# Altium schematic sheet eACU_mcux.SchDoc
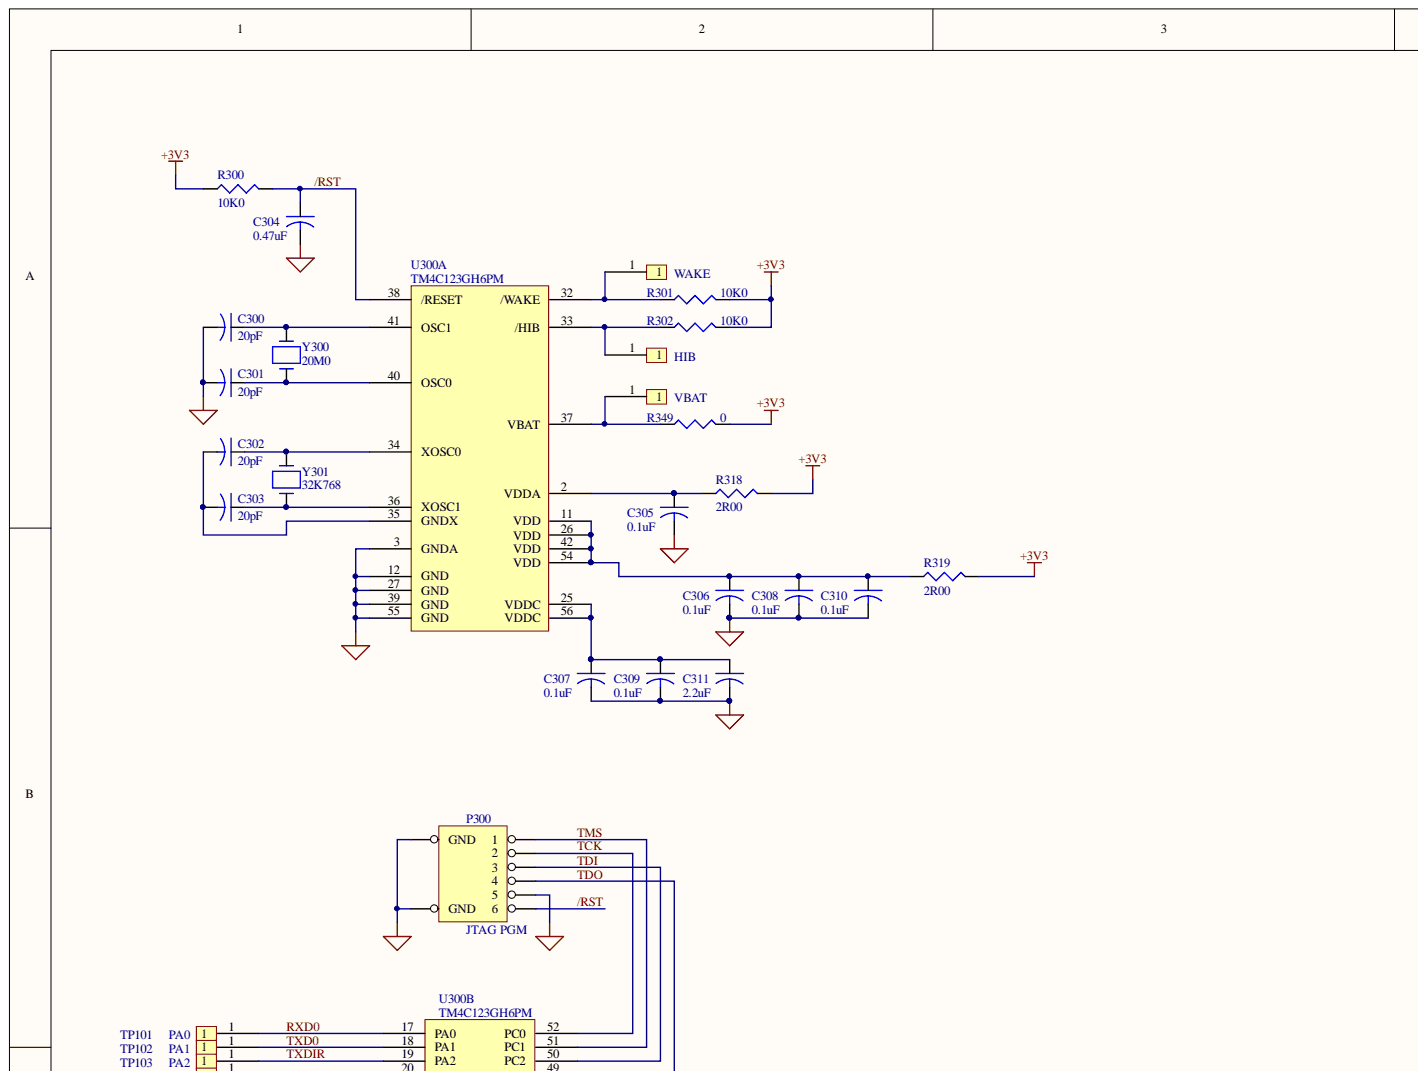
[diagram p0: eACU_mcux.SchDoc, top left]
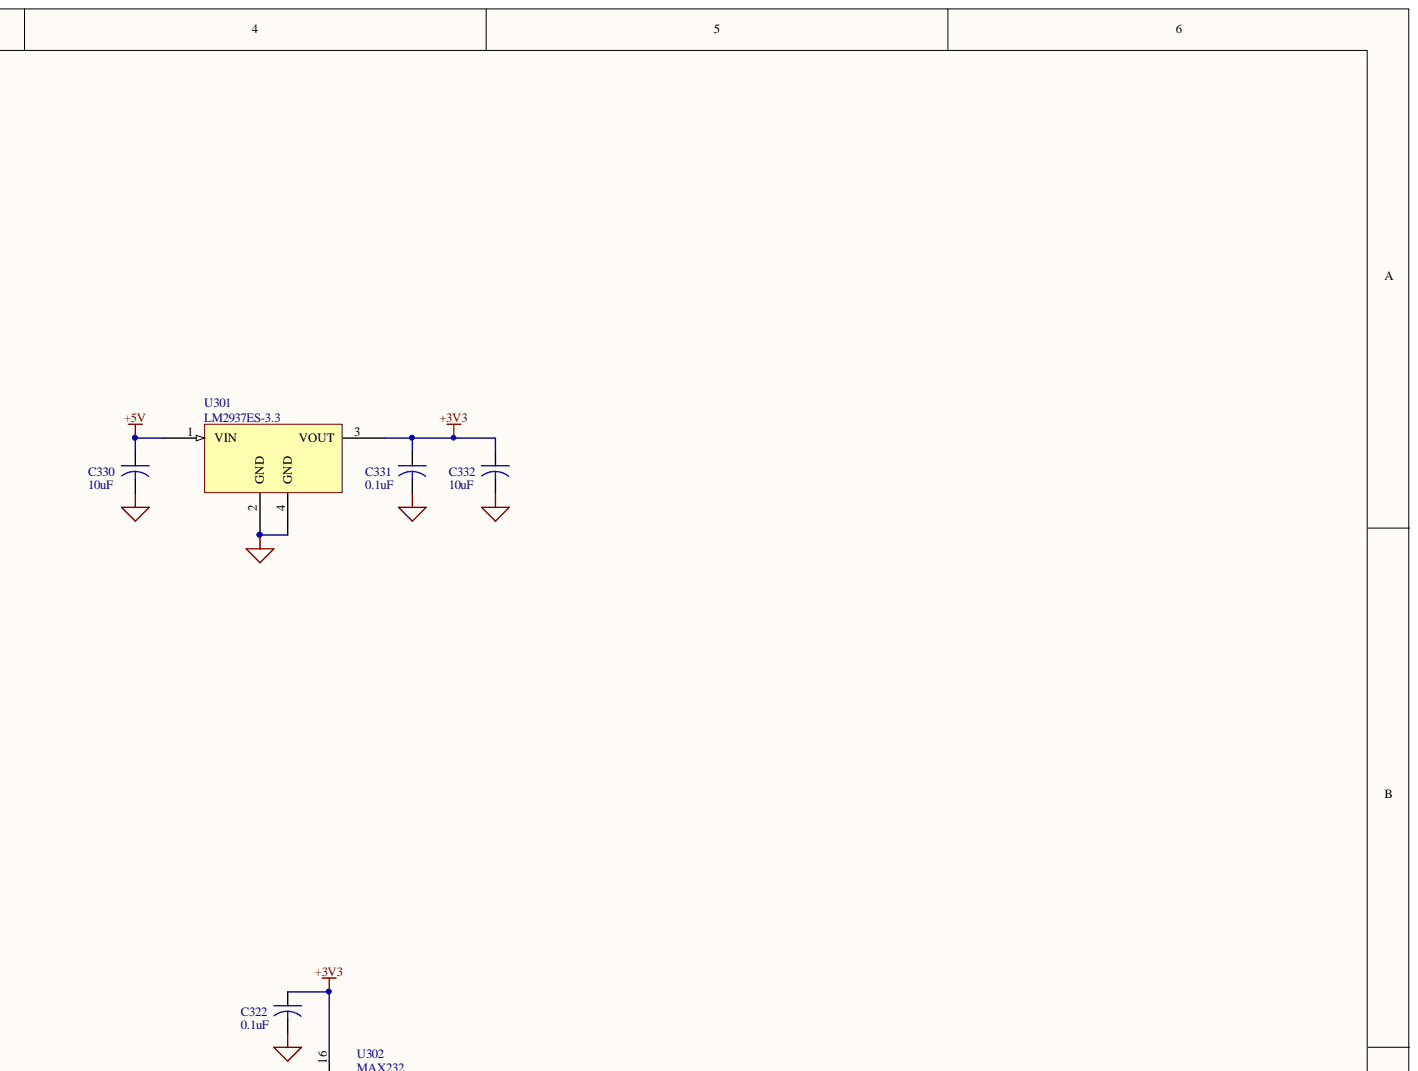
[diagram p1: eACU_mcux.SchDoc, top right]
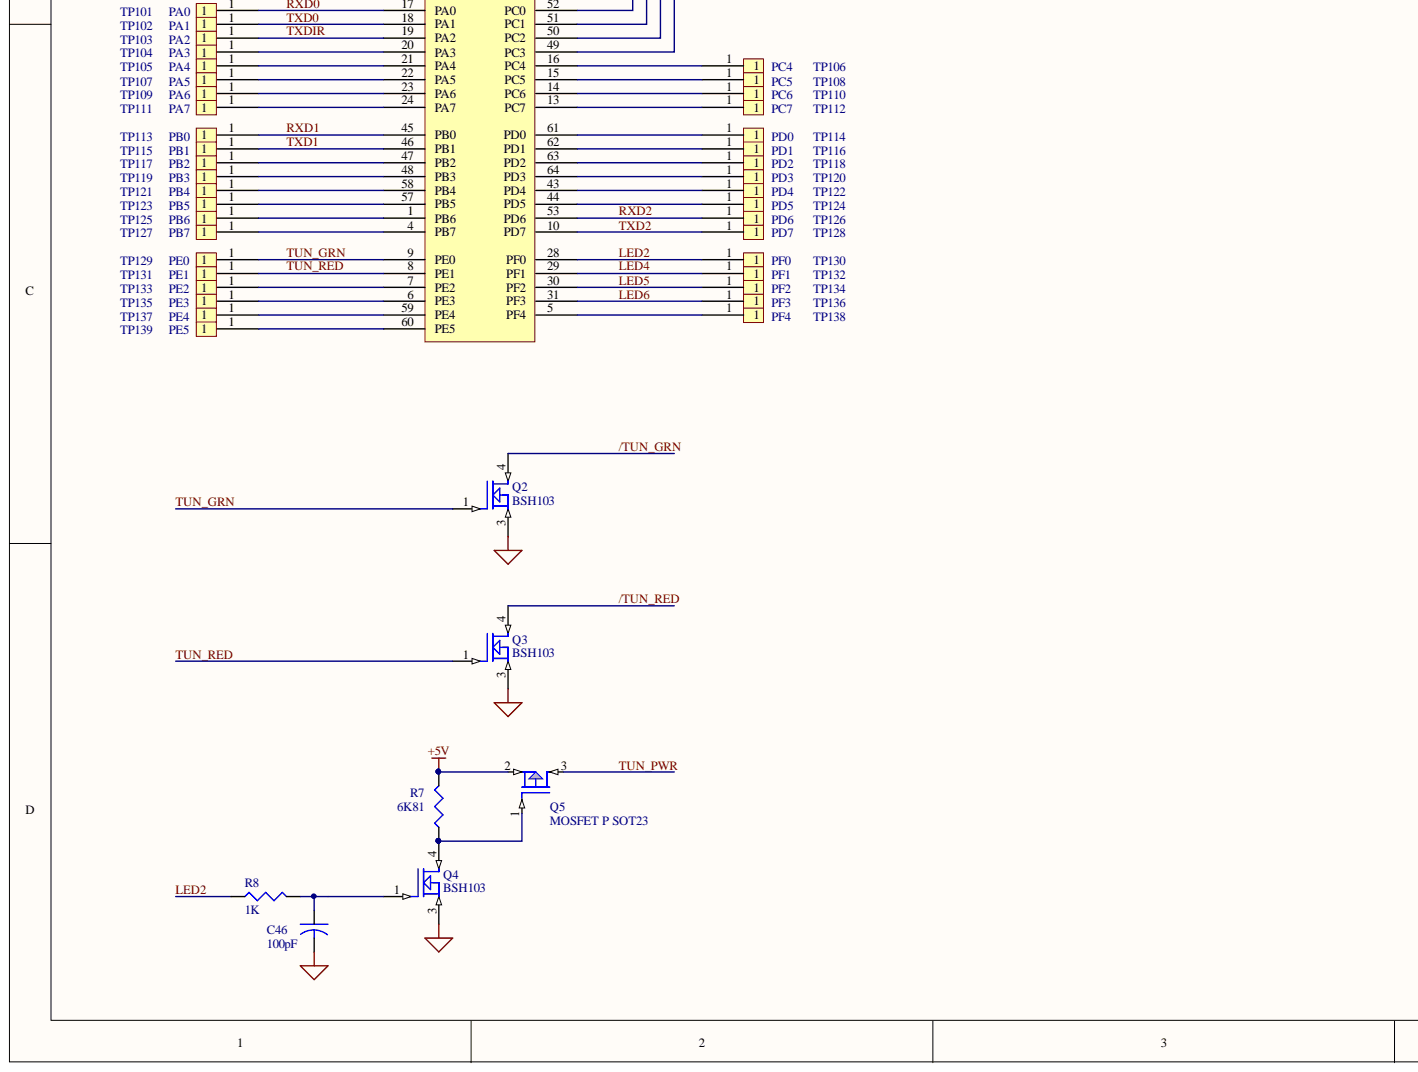
[diagram p2: eACU_mcux.SchDoc, bottom left]
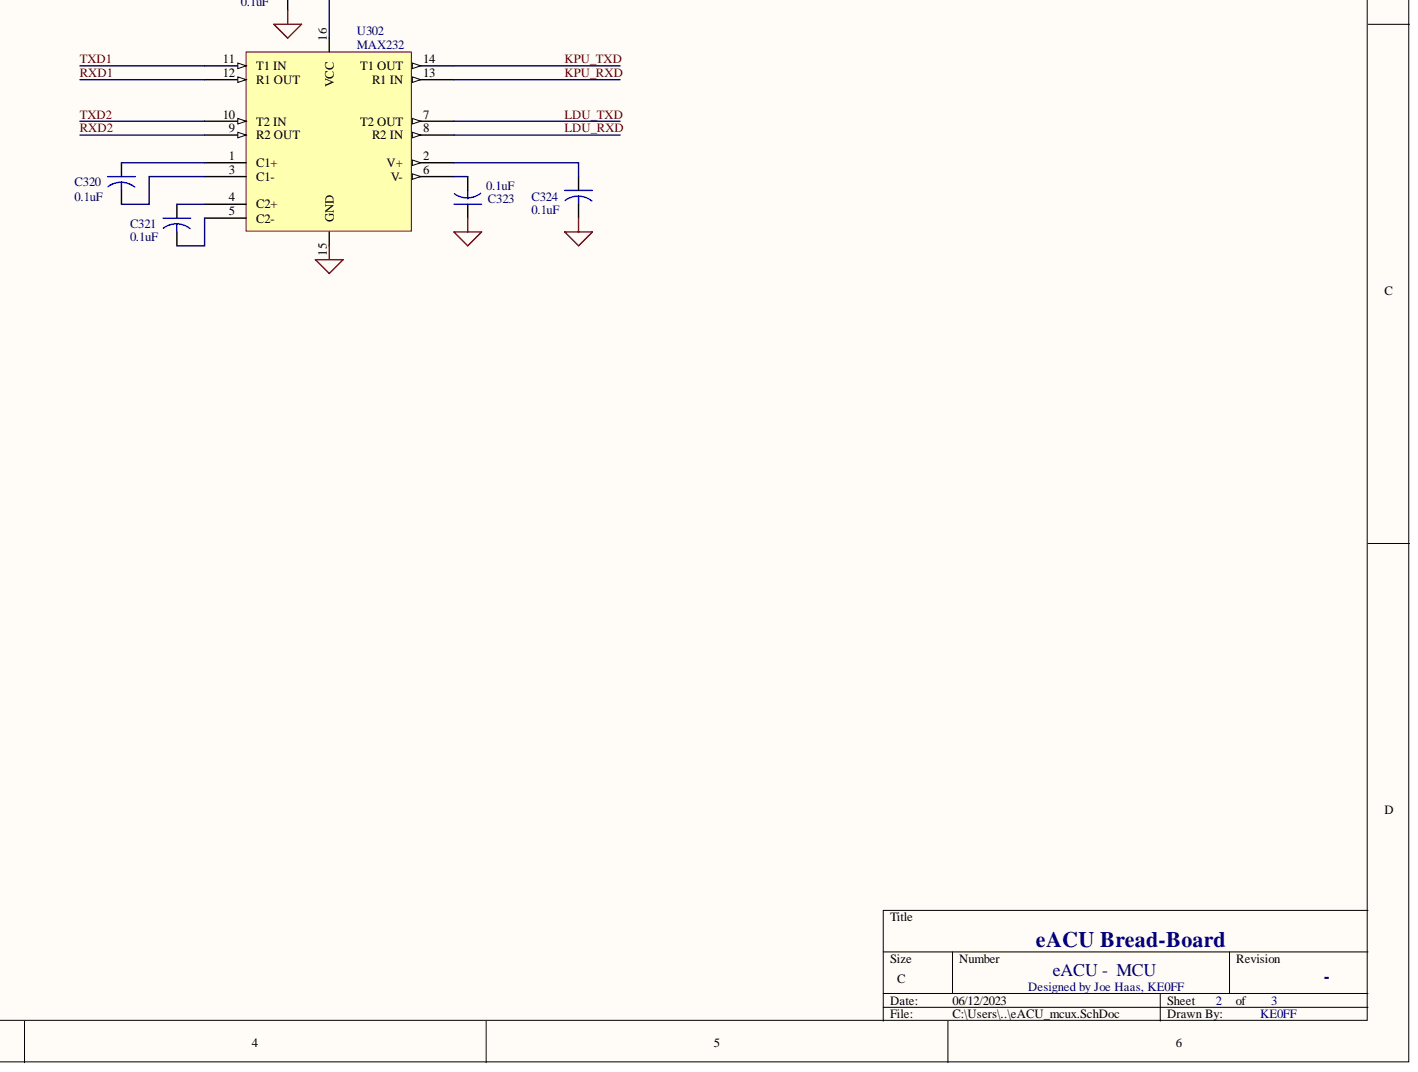
[diagram p3: eACU_mcux.SchDoc, bottom right]

## Parts
C46  100pF  [0402]
C300  20pF  [0402]
C301  20pF  [0402]
C302  20pF  [0402]
C303  20pF  [0402]
C304  0.47uF  [0805]
C305  0.1uF  [0402]
C306  0.1uF  [0402]
C307  0.1uF  [0402]
C308  0.1uF  [0402]
C309  0.1uF  [0402]
C310  0.1uF  [0402]
C311  2.2uF  [0402]
C320  0.1uF  [0402]
C321  0.1uF  [0402]
C322  0.1uF  [0402]
C323  0.1uF  [0402]
C324  0.1uF  [0402]
C330  10uF  [0402]
C331  0.1uF  [0402]
C332  10uF  [0402]
P300  JTAG PGM  [CON_1.25MM_6P]
Q2  BSH103  [TO252]
Q3  BSH103  [TO252]
Q4  BSH103  [TO252]
Q5  MOSFET P SOT23  [TO252]
R7  6K81  [0603]
R8  1K  [0402]
R300  10K0  [0402]
R301  10K0  [0603]
R302  10K0  [0603]
R318  2R00  [0402]
R319  2R00  [0402]
R349  0  [0603]
TP101  PA0  [TP-SMD-20x50-SQ]
TP102  PA1  [TP-SMD-20x50-SQ]
TP103  PA2  [TP-SMD-20x50-SQ]
TP104  PA3  [TP-SMD-20x50-SQ]
TP105  PA4  [TP-SMD-20x50-SQ]
TP106  PC4  [TP-SMD-20x50-SQ]
TP107  PA5  [TP-SMD-20x50-SQ]
TP108  PC5  [TP-SMD-20x50-SQ]
TP109  PA6  [TP-SMD-20x50-SQ]
TP110  PC6  [TP-SMD-20x50-SQ]
TP111  PA7  [TP-SMD-20x50-SQ]
TP112  PC7  [TP-SMD-20x50-SQ]
TP113  PB0  [TP-SMD-20x50-SQ]
TP114  PD0  [TP-SMD-20x50-SQ]
TP115  PB1  [TP-SMD-20x50-SQ]
TP116  PD1  [TP-SMD-20x50-SQ]
TP117  PB2  [TP-SMD-20x50-SQ]
TP118  PD2  [TP-SMD-20x50-SQ]
TP119  PB3  [TP-SMD-20x50-SQ]
TP120  PD3  [TP-SMD-20x50-SQ]
TP121  PB4  [TP-SMD-20x50-SQ]
TP122  PD4  [TP-SMD-20x50-SQ]
TP123  PB5  [TP-SMD-20x50-SQ]
TP124  PD5  [TP-SMD-20x50-SQ]
TP125  PB6  [TP-SMD-20x50-SQ]
TP126  PD6  [TP-SMD-20x50-SQ]
TP127  PB7  [TP-SMD-20x50-SQ]
TP128  PD7  [TP-SMD-20x50-SQ]
TP129  PE0  [TP-SMD-20x50-SQ]
TP130  PF0  [TP-SMD-20x50-SQ]
TP131  PE1  [TP-SMD-20x50-SQ]
TP132  PF1  [TP-SMD-20x50-SQ]
TP133  PE2  [TP-SMD-20x50-SQ]
TP134  PF2  [TP-SMD-20x50-SQ]
TP135  PE3  [TP-SMD-20x50-SQ]
TP136  PF3  [TP-SMD-20x50-SQ]
TP137  PE4  [TP-SMD-20x50-SQ]
TP138  PF4  [TP-SMD-20x50-SQ]
TP139  PE5  [TP-SMD-20x50-SQ]
TP300  HIB  [TP-SMD-20x50-SQ]
TP301  WAKE  [TP-SMD-20x50-SQ]
TP302  VBAT  [TP-SMD-20x50-SQ]
U300  TM4C123GH6PM  [TQFP-64]  (parts A,B)
U301  LM2937ES-3.3  [TO-263]
U302  MAX232  [SO16]
Y300  20M0  [XTAL_SMD]
Y301  32K768  [XTAL_AB26TRB]

## Nets
3: Y301.3
+3V3: C322.1 C331.1 C332.1 R300.1 R301.2 R302.2 R318.2 R319.2 R349.2 U301.3(VOUT) U302.16(VCC)
+5V: C330.1 Q5.2 R7.2 U301.1(VIN)
GND: C46.2
GNDK: C300.2 C301.2 C304.2 C305.2 C306.2 C307.2 C308.2 C309.2 C310.2 C311.2 C322.2 C323.1 C324.2 C330.2 C331.2 C332.2 P300.5 P300.7(GND) P300.8(GND) Q2.3 Q3.3 Q4.3 U300.3(GNDA) U300.12(GND) U300.27(GND) U300.39(GND) U300.55(GND) U301.2(GND) U301.4(GND) U302.15(GND)
/RST: C304.1 P300.6 R300.2 U300.38(/RESET)
/TUN_GRN: Q2.4
/TUN_RED: Q3.4
KPU_RXD: U302.13(R1_IN)
KPU_TXD: U302.14(T1_OUT)
LDU_RXD: U302.8(R2_IN)
LDU_TXD: U302.7(T2_OUT)
LED2: R8.1 TP130.1 U300.28(PF0)
LED4: TP132.1 U300.29(PF1)
LED5: TP134.1 U300.30(PF2)
LED6: TP136.1 U300.31(PF3)
RXD0: TP101.1 U300.17(PA0)
RXD1: TP113.1 U300.45(PB0) U302.12(R1_OUT)
RXD2: TP126.1 U300.53(PD6) U302.9(R2_OUT)
TCK: P300.2 U300.52(PC0)
TDI: P300.3 U300.50(PC2)
TDO: P300.4 U300.49(PC3)
TMS: P300.1 U300.51(PC1)
TUN_GRN: Q2.1 TP129.1 U300.9(PE0)
TUN_PWR: Q5.3
TUN_RED: Q3.1 TP131.1 U300.8(PE1)
TXD0: TP102.1 U300.18(PA1)
TXD1: TP115.1 U300.46(PB1) U302.11(T1_IN)
TXD2: TP128.1 U300.10(PD7) U302.10(T2_IN)
TXDIR: TP103.1 U300.19(PA2)
NetC46_1: C46.1 Q4.1 R8.2
NetC300_1: C300.1 U300.41(OSC1) Y300.2
NetC301_1: C301.1 U300.40(OSC0) Y300.1
NetC302_1: C302.1 U300.34(XOSC0) Y301.2
NetC302_2: C302.2 C303.2 U300.35(GNDX)
NetC303_1: C303.1 U300.36(XOSC1) Y301.1
NetC305_1: C305.1 R318.1 U300.2(VDDA)
NetC306_1: C306.1 C308.1 C310.1 R319.1 U300.11(VDD) U300.26(VDD) U300.42(VDD) U300.54(VDD)
NetC307_1: C307.1 C309.1 C311.1 U300.25(VDDC) U300.56(VDDC)
NetC320_1: C320.1 U302.1(C1+)
NetC320_2: C320.2 U302.3(C1-)
NetC321_1: C321.1 U302.4(C2+)
NetC321_2: C321.2 U302.5(C2-)
NetC323_2: C323.2 U302.6(V-)
NetC324_1: C324.1 U302.2(V+)
NetQ4_4: Q4.4 Q5.1 R7.1
NetR301_1: R301.1 TP301.1 U300.32(/WAKE)
NetR302_1: R302.1 TP300.1 U300.33(/HIB)
NetR349_1: R349.1 TP302.1 U300.37(VBAT)
NetTP104_1: TP104.1 U300.20(PA3)
NetTP105_1: TP105.1 U300.21(PA4)
NetTP106_1: TP106.1 U300.16(PC4)
NetTP107_1: TP107.1 U300.22(PA5)
NetTP108_1: TP108.1 U300.15(PC5)
NetTP109_1: TP109.1 U300.23(PA6)
NetTP110_1: TP110.1 U300.14(PC6)
NetTP111_1: TP111.1 U300.24(PA7)
NetTP112_1: TP112.1 U300.13(PC7)
NetTP114_1: TP114.1 U300.61(PD0)
NetTP116_1: TP116.1 U300.62(PD1)
NetTP117_1: TP117.1 U300.47(PB2)
NetTP118_1: TP118.1 U300.63(PD2)
NetTP119_1: TP119.1 U300.48(PB3)
NetTP120_1: TP120.1 U300.64(PD3)
NetTP121_1: TP121.1 U300.58(PB4)
NetTP122_1: TP122.1 U300.43(PD4)
NetTP123_1: TP123.1 U300.57(PB5)
NetTP124_1: TP124.1 U300.44(PD5)
NetTP125_1: TP125.1 U300.1(PB6)
NetTP127_1: TP127.1 U300.4(PB7)
NetTP133_1: TP133.1 U300.7(PE2)
NetTP135_1: TP135.1 U300.6(PE3)
NetTP137_1: TP137.1 U300.59(PE4)
NetTP138_1: TP138.1 U300.5(PF4)
NetTP139_1: TP139.1 U300.60(PE5)

## Library data of the parts
C46 C300-C311 C320-C324 C330-C332 = CAP 0805: Component Kind: Standard
P300 = HEADER-6-PIN-RT-ANG: 6-PIN JTAG HDR; DPN: P10804; Component Kind: Standard
Q2-Q4 = MOSFET N: TR, FET, N-CH; ALT-MPN: -; Component Kind: Standard; DNP: -; MFR: -; MPN: -; NOTES: -; NOTES2: -; PRI-MPN: -; Library Name: Galaxy SCH-jem-1.08.SchLib
Q5 = MOSFET P SOT23: TR, FET, P-CH; ALT-MPN: -; Component Kind: Standard; DNP: -; MFR: -; MPN: -; NOTES: -; NOTES2: -; PRI-MPN: -; Library Name: Galaxy SCH-jem-1.08.SchLib
R7 R8 R300-R302 R318 R319 R349 = R0805: Text Field1: 20; Text Field2: 30; Component Kind: Standard
TP101-TP139 TP300-TP302 = TP: Component Kind: Standard
U300 = TM4C123GH6PM: IC, MCU, ARM, TI; Component Kind: Standard
U301 = LM2937ES-3.3: LM2937ET-3.3; ALT-MPN: -; Component Kind: Standard; DNP: -; MFR: -; MPN: -; NOTES: -; NOTES2: -; PRI-MPN: -; Library Name: Galaxy SCH-jem-1.08.SchLib
U302 = MAX232: IC, XCVR, RS232, +5V; ALT-MPN: -; Component Kind: Standard; DNP: -; MFR: -; MPN: -; NOTES: -; NOTES2: -; PRI-MPN: -; Library Name: Galaxy SCH-jem-1.08.SchLib
Y300 = CRYSTAL-2PIN: CRYSTAL 2 PIN; Part Field 1: 445C25D20M00000; Text Field1: 20; Component Kind: Standard; Text Field2: 20
Y301 = CRYSTAL-3PIN: CRYSTAL 3 PIN; Text Field1: 20; Component Kind: Standard; Text Field2: 20
